# Altium schematic sheet Power.SchDoc(Power)
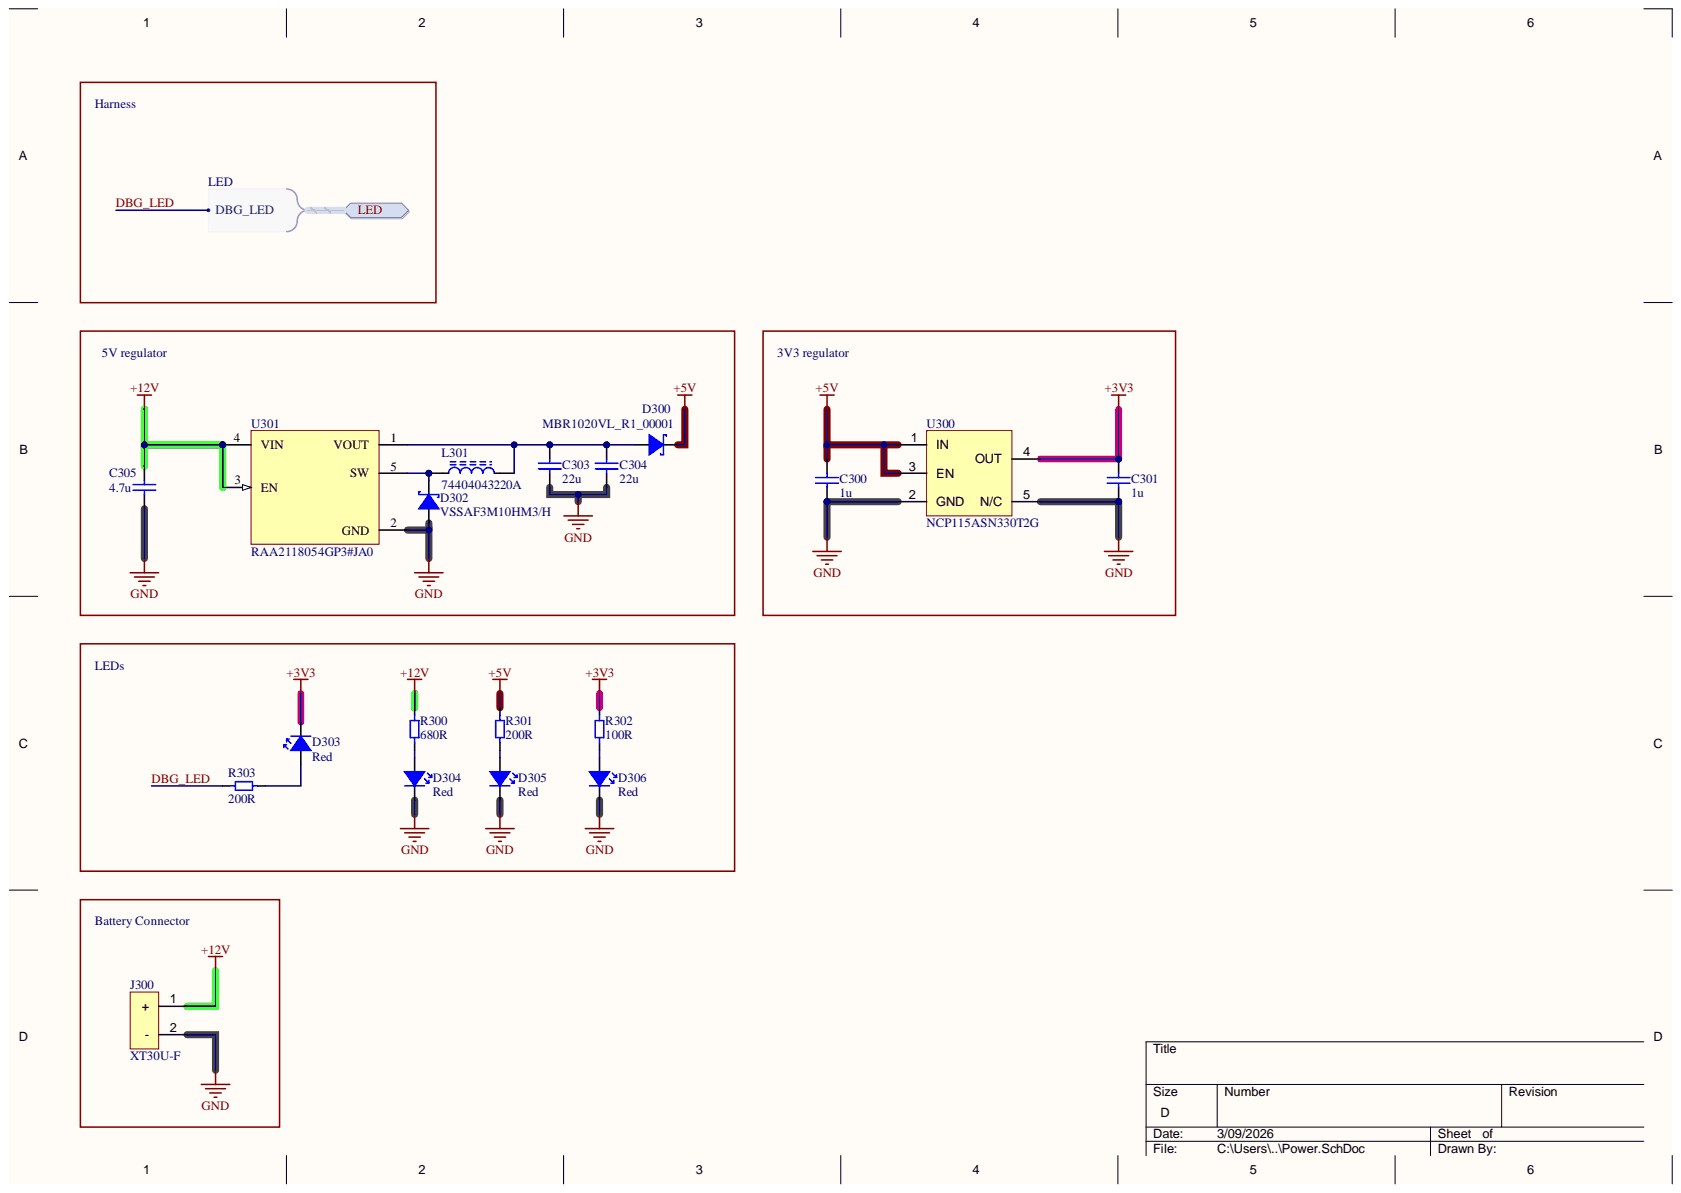
[diagram p0: Power.SchDoc]

## Parts
C300  1u  [MLCC_0603_M]
C301  1u  [MLCC_0603_M]
C303  22u  [MLCC_0603_M]
C304  22u  [MLCC_0603_M]
C305  4.7u  [MLCC_1206_M]
D300  MBR1020VL_R1_00001  [FP-MBR1020VL_R1_00001-MFG]
D302  VSSAF3M10HM3/H  [VSSAF3M10HM3_H-Footprint-1]
D303  Red  [0603 RED LED]
D304  Red  [0603 RED LED]
D305  Red  [0603 RED LED]
D306  Red  [0603 RED LED]
J300  XT30U-F  [XT30U-F]
L301  74404043220A  [WE-LQS_4025]
R300  680R  [Resistor_0603_M]
R301  200R  [Resistor_0603_M]
R302  100R  [Resistor_0603_M]
R303  200R  [Resistor_0603_M]
U300  NCP115ASN330T2G  [TSOP-5]
U301  RAA2118054GP3#JA0  [P5_064B_MFG]

## Ports
LED, LED.DBG_LED

## Nets
+3V3: C301.2 D303.1 R302.2 U300.4(OUT)
+5V: C300.2 D300.1 R301.1 U300.1(IN) U300.3(EN)
+12V: C305.2 J300.1 R300.2 U301.3(EN) U301.4(VIN)
GND: C300.1 C301.1 C303.1 C304.1 C305.1 D302.2 D304.1 D305.1 D306.1 J300.2 U300.2(GND) U300.5(N/C) U301.2(GND)
DBG_LED: R303.1 ; port LED
NetC303_2: C303.2 C304.2 D300.2 L301.2 U301.1(VOUT)
NetD302_1: D302.1 L301.1 U301.5(SW)
NetD303_2: D303.2 R303.2
NetD304_2: D304.2 R300.1
NetD305_2: D305.2 R301.2
NetD306_2: D306.2 R302.1

## Library data of the parts
C300 C301 = CMP-001-0565-1: MLCC, 0603, 1u, 10%, 50V, X5R; Manufacturer: Any; Capacitor Type: Ceramic; Value: 1µF; Case/Package: 0603; Component Kind: Standard; Dielectric: X5R; Mount: SMD; Part number: MLCC, 0603, 1u, 10%, 50V, X5R; RoHS: Yes; Tolerance: 10%; Voltage: 50V; Library Name: Krakul Vault
C303 C304 = CMP-001-1264-1: MLCC, 0603, 22u, 10%, 16V, X5R; Manufacturer: Any; Capacitor Type: Ceramic; Value: 22µF; Case/Package: 0603; Component Kind: Standard; Dielectric: X5R; Mount: SMD; Part number: MLCC, 0603, 22u, 10%, 16V, X5R; RoHS: Yes; Tolerance: 10%; Voltage: 16V; Library Name: Krakul Vault
C305 = CMP-001-1124-2: MLCC, 1206, 4.7u, 10%, 35V, X5R; Manufacturer: Any; Capacitor Type: Ceramic; Value: 4,7µF; Case/Package: 1206; Component Kind: Standard; Dielectric: X5R; Mount: SMD; Part number: MLCC, 1206, 4.7u, 10%, 35V, X5R; RoHS: Yes; Tolerance: 10%; Voltage: 35V; Library Name: Krakul Vault
D300 = CMP-ROOT-01310-1: Rectifier Diode, Schottky, 1 Phase, 1 Element, 1A, 20V V(RRM), Silicon; Manufacturer: Panjit; Max Forward Surge Current (Ifsm): 45A; Max Junction Temperature (Tj): 125°C; Number of Elements: 1; Peak Reverse Current: 600µA; Case/Package: SOD-123FL; Component Kind: Standard; Forward Current: 1A; Height: 1.1mm; Lifecycle Status: Production; Max Operating Temperature: 125°C; Max Output current: 1A; Max Repetitive Reverse Voltage (Vrrm): 20V; Min Operating Temperature: -55°C; Mount: SMD; Number of Terminals: 2; Part number: MBR1020VL_R1_00001; Pins: 2; REACH SVHC: No; Reverse Standoff Voltage: 20V; RoHS: Compliant; Library Name: Krakul Vault
D302 = CMP-ROOT-01308-1: Rectifier Diode, Schottky, 1 Phase, 1 Element, 2.3A, 100V V(RRM), Silicon, DO-221AC; Forward Voltage: 720mV; Max Forward Surge Current (Ifsm): 80A; Number of Elements: 1; Peak Reverse Current: 200µA; Case/Package: DO-221AC-2; Component Kind: Standard; Lifecycle Status: Production; Manufacturer: VSSAF3M10HM3/H; Max Operating Temperature: 175°C; Max Output current: 2.3A; Min Operating Temperature: -40°C; Mount: SMD; Number of Terminals: 2; Part number: VSSAF3M10HM3/H; Pins: 2; REACH SVHC: No; Reverse Standoff Voltage: 100V; RoHS: Compliant; Library Name: Krakul Vault
D303-D306 = CMP-ROOT-00025-2: Generic red 0603 LED; Manufacturer: Any; Part number: Any Red 0603 LED; Case/Package: 0603; Color: Red; Component Kind: Standard; Mount: SMD; RoHS: Yes; Library Name: Krakul Vault
J300 = CMP-ROOT-01262-3: Power Connector; Manufacturer: AMASS; Case/Package: Non-standard; Color: Yellow; Component Kind: Standard; Contact Material: Brass; Mount: SMD; Orientation: Straight; Part number: XT30U-F; Pins: 2; RoHS: Yes; Library Name: Krakul Vault
L301 = CMP-ROOT-01309-1: General Purpose Inductor, 22uH, 20%, 1 Element, Nickel-zinc Ferrite-Core, SMD, 1616; Manufacturer: Wurth Elektronik; Current Rating: 1.11A; DC Resistance (DCR): 200mΩ; Value: 22µH; Ambient Temperature Range High: 85°C; Ambient Temperature Range Low: -40°C; Case/Package: 4mm x 4mm; Component Kind: Standard; Height: 2.5mm; Inductance Tolerance: 20%; Length: 4mm; Max Operating Temperature: 125°C; Min Operating Temperature: -40°C; Mount: SMD; Part number: 74404043220A; Pins: 2; RoHS: Compliant; Width: 4mm; Library Name: Krakul Vault
R300 = CMP-001-0074-3: Resistor, 0603, 680R, 1%, 1/10W; Manufacturer: Any; Power: 100mW; Value: 680; Case/Package: 0603; Component Kind: Standard; Mount: SMD; Part number: Resistor, 0603, 680R, 1%, 1/10W; RoHS: Yes; Tolerance: 1%; Library Name: Krakul Vault
R301 R303 = CMP-001-0060-2: Resistor, 0603, 200R, 1%, 1/10W; Manufacturer: Any; Power: 100mW; Value: 200; Case/Package: 0603; Component Kind: Standard; Mount: SMD; Part number: Resistor, 0603, 200R, 1%, 1/10W; RoHS: Yes; Tolerance: 1%; Library Name: Krakul Vault
R302 = CMP-0001-00262-1: Resistor, 0603, 100R, ≤5%, ≥1/16W; Manufacturer: Any; Value: 100; Case/Package: 0603; Component Kind: Standard; Mount: SMD; Part number: Resistor, 0603, 100R, ≤5%, ≥1/16W; RoHS: Yes; Library Name: Krakul Vault
U300 = CMP-00026-1: LDO Regulator, 300 mA, Low Iq, High PSRR, High Accuracy 3.3V, TSOP5, Active Discharge, Normal Vout Slew-Rate; Manufacturer: onsemi; Accuracy: 1%; Dropout Voltage: 235mV; Max Junction Temperature (Tj): 85°C; Max Supply Voltage: 5.5V; Min Input Voltage: 3.8V; Min Supply Voltage: 1.7V; Nominal Supply Current: 50µA; Number of Channels: 1; Output Current: 300mA; Output Type: Fixed; Voltage Tolerance: 2%; Case/Package: TSOP-5; China RoHS: Compliant; Component Kind: Standard; Height: 1.1mm; Height - Seated (Max): 1.1mm; Length: 3mm; Lifecycle Status: Production; Manufacturer Lifecycle Status: ACTIVE; Max Operating Temperature: 150°C; Mount: SMD; Number of Circuits: 1; Number of Outputs: 1; Number of Terminals: 5; Output Voltage: 3.3V; Part number: NCP115ASN330T2G; REACH SVHC: Yes; RoHS: Compliant; Schedule B: 8542390000, 8542390000; Terminal Pitch: 950µm; Width: 1.5mm; Library Name: Krakul Vault
U301 = CMP-00019-3: Switching Regulator, Current-mode, 0.3A, 230kHz Switching Freq-Max, PDSO5; Manufacturer: Renesas / Intersil; Manufacturer Lifecycle Status: ACTIVE; Max Junction Temperature (Tj): 125°C; Max Output current: 300mA; Max Output Voltage: 5.15V; Max Supply Voltage: 80V; Min Input Voltage: 7V; Min Output Voltage: 3.18V; Nominal Output Voltage: 5V; Nominal Supply Voltage: 18V; Number of Circuits: 1; Pitch: 1mm; Topology: Buck; Case/Package: TSOT; Component Kind: Standard; Export Control Classification Number (ECCN) Code: EAR99; Harmonized Tariff Schedule (HTS) Code: 8540000000; Height: 850µm; Height - Seated (Max): 1.1mm; Length: 2.9mm; Lifecycle Status: Production; Max Operating Temperature: 125°C; Max Switching Frequency: 230kHz; Min Operating Temperature: -40°C; Mount: SMD; Mounting Technology: Surface Mount; MSL: 3; Number of Terminals: 5; Package Quantity: 3000; Part Family: RAA211805; Part number: RAA2118054GP3#JA0; Pins: 5; REACH SVHC: Yes; RoHS: Compliant; Terminal Pitch: 950µm; Width: 1.6mm; Library Name: Krakul Vault
